# Altium schematic sheet main.SchDoc
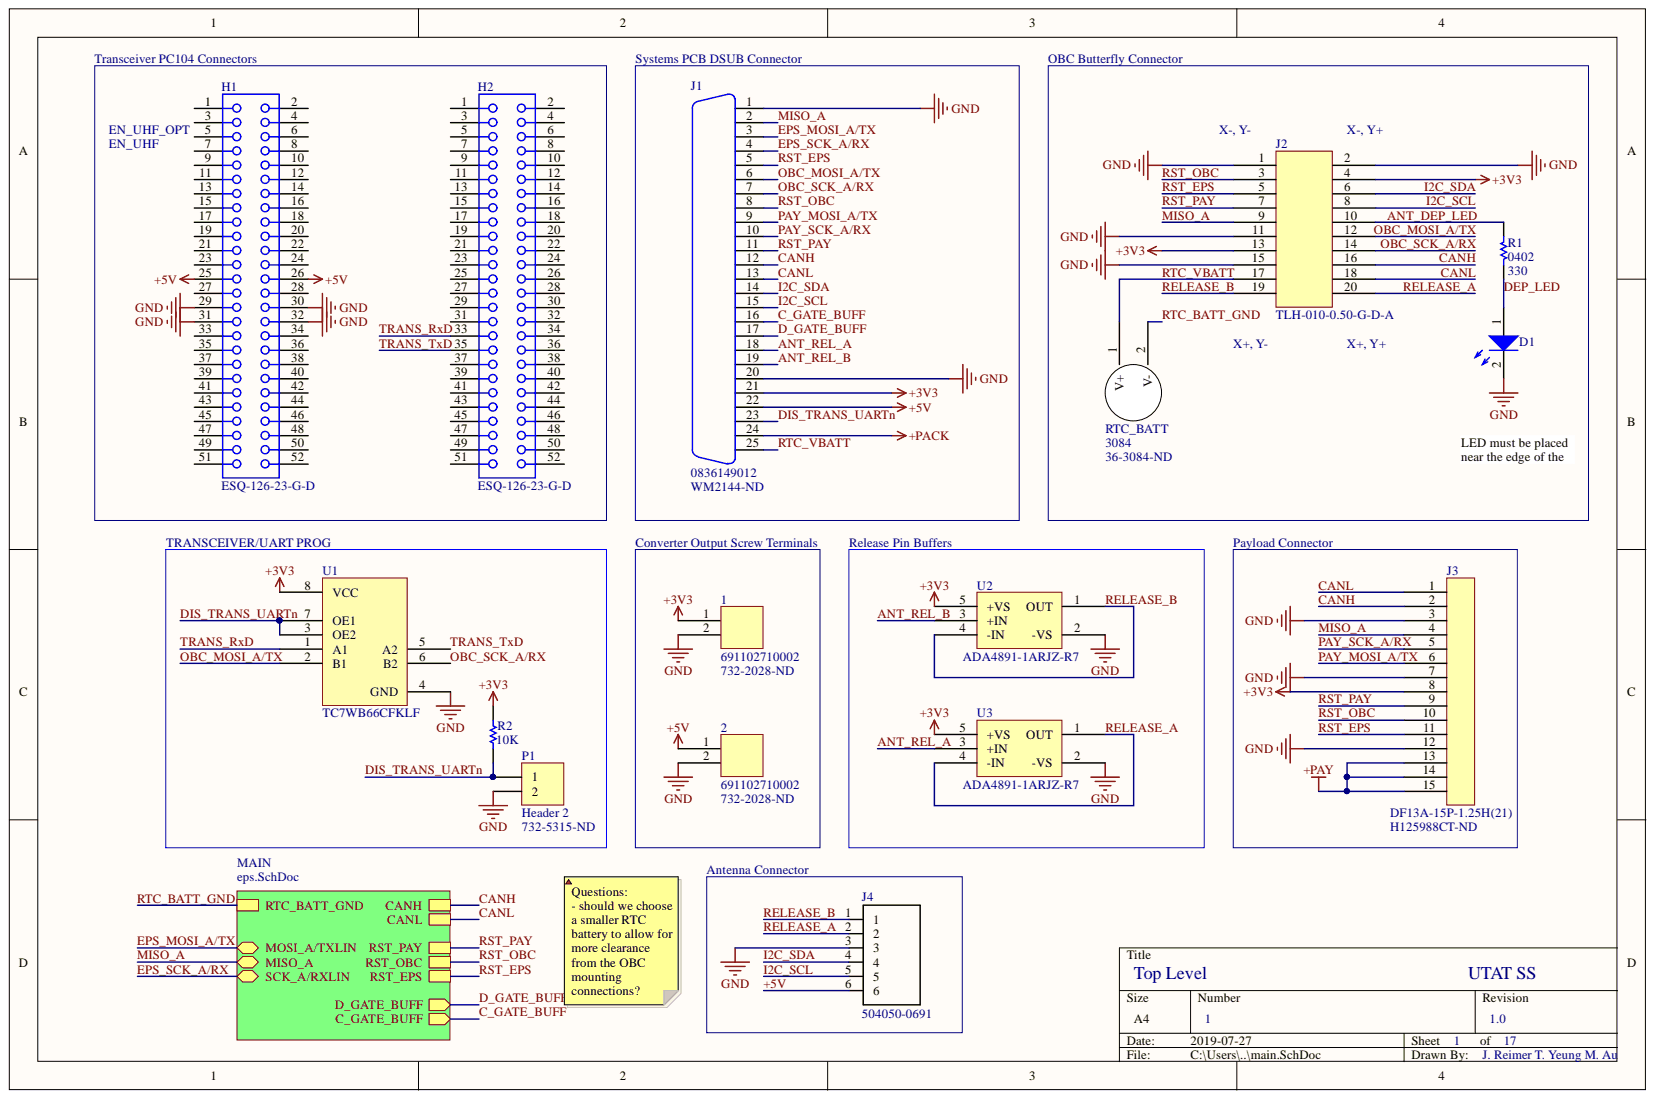
[diagram p0: main.SchDoc]

## Parts
1  691102710002  Supplier Part Number 1: 732-2028-ND  [CON2_SCREW_5.00MM_691102710002]
2  691102710002  Supplier Part Number 1: 732-2028-ND  [CON2_SCREW_5.00MM_691102710002]
D1  (no value)  [LED0603]
H1  ESQ-126-23-G-D  [SSW-126-01-G-D]
H2  ESQ-126-23-G-D  [SSW-126-01-G-D]
J1  0836149012  Supplier Part Number 1: WM2144-ND  [0836149012]
J2  TLH-010-0.50-G-D-A  [TLH-010-0.50-G-D-A]
J3  DF13A-15P-1.25H(21)  Supplier Part Number 1: H125988CT-ND  [DF13A-15P-1.25H(21)]
J4  504050-0691  [MOLEX_504050-0691]
P1  Header 2  Supplier Part Number 1: 732-5315-ND  [HDR1X2]
R1  0402  value 330  [RES0402R]
R2  value 10K  [RES0402R]  (hidden comment: 0402)
RTC_BATT  3084  [3084]
U1  TC7WB66CFKLF  [US8]
U2  ADA4891-1ARJZ-R7  [SOT-23-5]
U3  ADA4891-1ARJZ-R7  [SOT-23-5]

## Nets
+3V3: 1.1 J1.21 J2.4 J2.13 J3.8 R2.2 U1.8(VCC) U2.5(+VS) U3.5(+VS)
+PACK: J1.24
+PAY: J3.13 J3.14 J3.15
GND: 1.2 2.2 D1.2 H1.29 H1.30 H1.31 H1.32 J1.1 J1.20 J2.1 J2.2 J2.11 J2.15 J3.3 J3.7 J3.12 J4.3 P1.2 U1.4(GND) U2.2(-VS) U3.2(-VS)
+5V: 2.1 H1.25 H1.26 J1.22 J4.6
ANT_DEP_LED: J2.10 R1.2
ANT_REL_A: J1.18 U3.3(+IN)
ANT_REL_B: J1.19 U2.3(+IN)
C_GATE_BUFF: J1.16
CANH: J1.12 J2.16 J3.2
CANL: J1.13 J2.18 J3.1
D_GATE_BUFF: J1.17
DEP_LED: D1.1 R1.1
DIS_TRANS_UARTn: J1.23 P1.1 R2.1 U1.3(OE2) U1.7(OE1)
EPS_MOSI_A/TX: J1.3
EPS_SCK_A/RX: J1.4
I2C_SCL: J1.15 J2.8 J4.5
I2C_SDA: J1.14 J2.6 J4.4
MISO_A: J1.2 J2.9 J3.4
OBC_MOSI_A/TX: J1.6 J2.12 U1.2(B1)
OBC_SCK_A/RX: J1.7 J2.14 U1.6(B2)
PAY_MOSI_A/TX: J1.9 J3.6
PAY_SCK_A/RX: J1.10 J3.5
RELEASE_A: J2.20 J4.2 U3.1(OUT) U3.4(-IN)
RELEASE_B: J2.19 J4.1 U2.1(OUT) U2.4(-IN)
RST_EPS: J1.5 J2.5 J3.11
RST_OBC: J1.8 J2.3 J3.10
RST_PAY: J1.11 J2.7 J3.9
RTC_BATT_GND: RTC_BATT.2
RTC_VBATT: J1.25 J2.17 RTC_BATT.1
TRANS_RxD: H2.33 U1.1(A1)
TRANS_TxD: H2.35 U1.5(A2)
NetH1_1: H1.1
NetH1_2: H1.2
NetH1_3: H1.3
NetH1_4: H1.4
NetH1_5: H1.5
NetH1_6: H1.6
NetH1_7: H1.7
NetH1_8: H1.8
NetH1_9: H1.9
NetH1_10: H1.10
NetH1_11: H1.11
NetH1_12: H1.12
NetH1_13: H1.13
NetH1_14: H1.14
NetH1_15: H1.15
NetH1_16: H1.16
NetH1_17: H1.17
NetH1_18: H1.18
NetH1_19: H1.19
NetH1_20: H1.20
NetH1_21: H1.21
NetH1_22: H1.22
NetH1_23: H1.23
NetH1_24: H1.24
NetH1_27: H1.27
NetH1_28: H1.28
NetH1_33: H1.33
NetH1_34: H1.34
NetH1_35: H1.35
NetH1_36: H1.36
NetH1_37: H1.37
NetH1_38: H1.38
NetH1_39: H1.39
NetH1_40: H1.40
NetH1_41: H1.41
NetH1_42: H1.42
NetH1_43: H1.43
NetH1_44: H1.44
NetH1_45: H1.45
NetH1_46: H1.46
NetH1_47: H1.47
NetH1_48: H1.48
NetH1_49: H1.49
NetH1_50: H1.50
NetH1_51: H1.51
NetH1_52: H1.52
NetH2_1: H2.1
NetH2_2: H2.2
NetH2_3: H2.3
NetH2_4: H2.4
NetH2_5: H2.5
NetH2_6: H2.6
NetH2_7: H2.7
NetH2_8: H2.8
NetH2_9: H2.9
NetH2_10: H2.10
NetH2_11: H2.11
NetH2_12: H2.12
NetH2_13: H2.13
NetH2_14: H2.14
NetH2_15: H2.15
NetH2_16: H2.16
NetH2_17: H2.17
NetH2_18: H2.18
NetH2_19: H2.19
NetH2_20: H2.20
NetH2_21: H2.21
NetH2_22: H2.22
NetH2_23: H2.23
NetH2_24: H2.24
NetH2_25: H2.25
NetH2_26: H2.26
NetH2_27: H2.27
NetH2_28: H2.28
NetH2_29: H2.29
NetH2_30: H2.30
NetH2_31: H2.31
NetH2_32: H2.32
NetH2_34: H2.34
NetH2_36: H2.36
NetH2_37: H2.37
NetH2_38: H2.38
NetH2_39: H2.39
NetH2_40: H2.40
NetH2_41: H2.41
NetH2_42: H2.42
NetH2_43: H2.43
NetH2_44: H2.44
NetH2_45: H2.45
NetH2_46: H2.46
NetH2_47: H2.47
NetH2_48: H2.48
NetH2_49: H2.49
NetH2_50: H2.50
NetH2_51: H2.51
NetH2_52: H2.52

## Library data of the parts
1 2 = 691102710002: 2-POS screw terminal, 5mm pitch; Component Kind: Standard; Supplier 1: Digi-Key; Library Name: heron-general.IntLib
D1 = LED: Just a generic LED; Component Kind: Standard; Library Name: heron-general.IntLib
H1 H2 = ESQ-126-23-G-D: PC/104(TM) Elevated Socket Strip, Through-hole, Vertical, -55 to 125 degC, 2.54 mm Pitch, 52-Pin, Female, RoHS; Manufacturer: Samtec; Pitch: 2.54 mm; Component Kind: Standard; ComponentLink1Description: Manufacturer URL; ComponentLink1URL: http://www.samtec.com; ComponentLink2Description: Datasheet; ComponentLink2URL: http://www.samtec.com/documents/webfiles/pdf/ESQ_TH.PDF; ComponentLink3Description: Package Specification; ComponentLink3URL: http://www.samtec.com/documents/webfiles/cpdf/ESQ-1XX-XX-X-X-XXX-XX-X-XX-MKT.pdf; DatasheetVersion: F-214; Mounting Technology: Through-hole; Orientation: Vertical; PackageDescription: ESQ Strip ASM; PackageReference: ESQ-126-23-X-D; PackageVersion: BZ, 9/1998; PartNumber: ESQ-126-23-G-D; RoHS: True; Supplier 1: Newark; Supplier Part Number 1: 92P1348; Temperature Range: -55 to 125 degC
  H2: Library Name: CONNs.SchLib; Supplier 2: Digi-Key; Supplier Part Number 2: ESQ-126-23-G-D-ND
  H1: Library Name: heron-general.IntLib
J1 = 0836149012: Mini-DSUB 25-pos Connector; Component Kind: Standard; Supplier 1: Digi-Key; Library Name: heron-general.IntLib
J2 = TLH-010-0.50-G-D-A: 0.50 mm Razor Beam LP Ultra Low Profile Terminal Strip, alignment pin option; Component Kind: Standard; ComponentLink1Description: Product Page; ComponentLink1URL: https://www.samtec.com/products/tlh-010-0.50-g-d-a; Supplier 1: Samtec; Supplier Part Number 1: TLH-010-0.50-G-D-A; Library Name: heron-general.IntLib
J3 = DF13A-15P-1.25H(21): 15 pos DF13 right angle surface mount connector; Component Kind: Standard; Supplier 1: Digi-Key; Library Name: heron-general.IntLib
J4 = 504050-0691: Wire-To-Board Connector, Pico-Lock 504050 Series, Surface Mount, Header, 6, 1.5 mm; Component Kind: Standard; Library Name: heron-general.IntLib
P1 = Header 2: Header, 2-Pin; Component Kind: Standard; LatestRevisionDate: 17-Jul-2002; LatestRevisionNote: Re-released for DXP Platform.; Library Name: Miscellaneous Connectors.IntLib; Signal Integrity: Connector; Supplier 1: Digi-Key; Publisher: Altium Limited
R1 R2 = RES0402: 0402 resistor; Component Kind: Standard; Signal Integrity: Res; Simulation: RESISTOR; Library Name: heron-general.IntLib
RTC_BATT = 3084: CR2330 Battery Holder; Manufacturer: Keystone Electronics; Number of Batteries: 2; Number of Cells: 1; Case/Package: SMD/SMT; Component Kind: Standard; ComponentLink1Description: http://media.digikey.com/pdf/Data%20Sheets/Keystone%20Electronics%20PDFs/Lithium_Coin_Cell_Retainers_SMT.pdf?src-supplier=Digi-Key; ComponentLink1URL: http://media.digikey.com/pdf/Data%20Sheets/Keystone%20Electronics%20PDFs/Lithium_Coin_Cell_Retainers_SMT.pdf?src-supplier=Digi-Key; Lead Free: Lead Free; Manufacturer Part Number: 3084; Mount: Surface Mount,PCB; Package Quantity: 100; Pricing 1: 1=0.62, 10=0.523, 50=0.4586, 250=0.39796, 500=0.391, 1000=0.34946, 2500=0.31916, 5000=0.29492 (USD); REACH SVHC: No SVHC; Stock 1: 658; Supplier 1: Digi-Key; Supplier Part Number 1: 36-3084-ND; Termination: SMD/SMT; Library Name: heron-general.IntLib
U1 = TC7WB66CFKLF: Active high bus switch; Component Kind: Standard; Supplier 1: Digi-Key; Supplier Part Number 1: TC7WB66CFKLF(CTCT-ND; Library Name: heron-general.IntLib
U2 U3 = ADA4891-1ARJZ-R7: Rail-to-rail amplifier 125mA max; Built_By: EMA_UL_Team; Vendor: Analog Devices Inc; Component Kind: Standard; Manufacturer_Part_Number: ADA4891-1ARJZ-R7; RefDes: RefDes; Supplier 1: Digi-Key; Supplier Part Number 1: ADA4891-1ARJZ-R7CT-ND; Type: DEV; Value: Value; Copyright: Copyright (C) 2018 Accelerated Designs. All rights reserved; Library Name: heron-general.IntLib
